# Altium schematic sheet ShielArchiK9V2.SchDoc(ShielArchiK9V2)
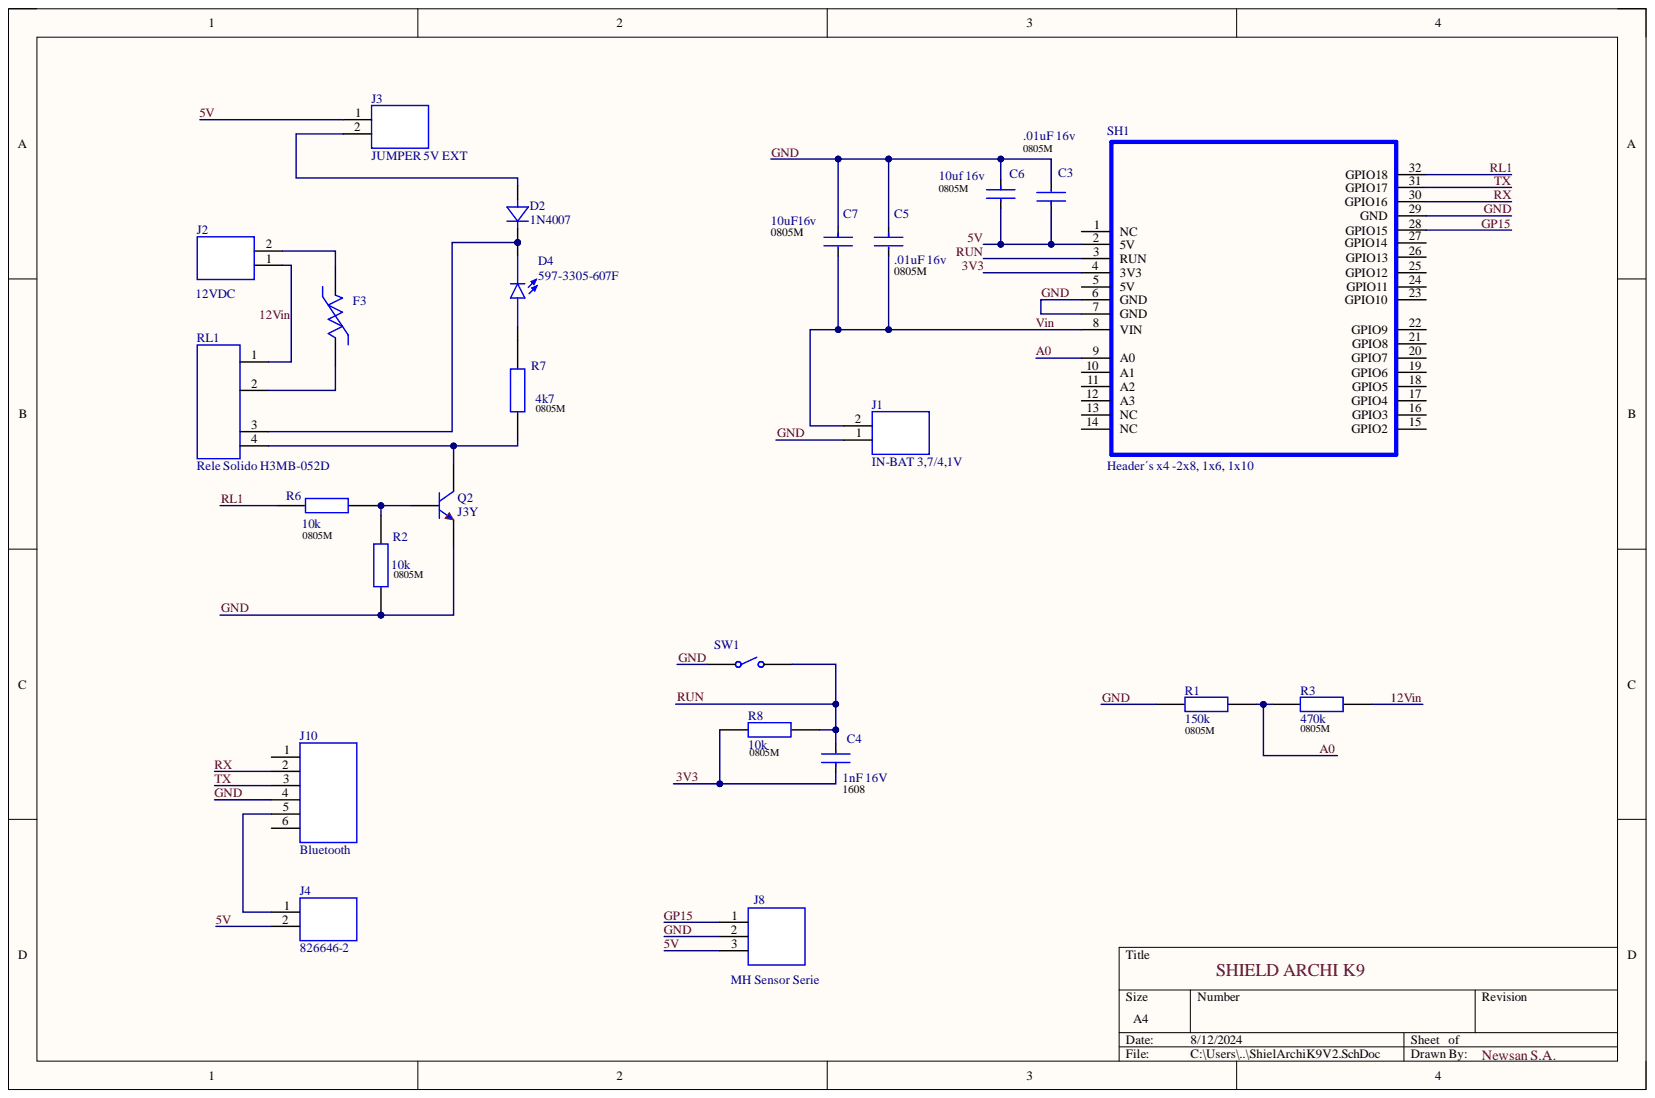
[diagram p0: ShielArchiK9V2.SchDoc]

## Parts
C3  .01uF 16v  [CC 106 10uf 16V 0805]
C4  1nF 16V  Voltage: 16V  [CC 106 10uf 16V 0805]
C5  .01uF 16v  [CC 106 10uf 16V 0805]
C6  10uf 16v  [CC 106 10uf 16V 0805]
C7  10uF16v  [CC 106 10uf 16V 0805]
D2  1N4007  [SOD123-M]
D4  597-3305-607F  [LEDC2012X70N]
F3  MF-MSMF050-2  [FP-MF-MSMF050-2-MFG]  (value not drawn)
J1  IN-BAT 3,7/4,1V  [PHOE-1729128-2_V]
J2  12VDC  [PHOE-1729128-2_V]
J3  JUMPER 5V EXT  [SAMTEC-HTSW-102-07-X-S]
J4  826646-2  [SAMTEC-HTSW-102-07-X-S]
J8  826646-3  [Header 3P 2.54mm]  (value not drawn)
J10  Bluetooth  [Header 6P 2.54mm]
Q2  J3Y  [ONSC-SOT-23-3-318-08_V - duplicate]
R1  150k  [RESC1609X50X30ML10T20]
R2  10k  [RESC1609X50X30ML10T20]
R3  470k  [RESC1609X50X30ML10T20]
R6  10k  [RESC1609X50X30ML10T20]
R7  4k7  [RESC1609X50X30ML10T20]
R8  10k  [RESC1609X50X30ML10T20]
RL1  Rele Solido H3MB-052D  [Rele Solido]
SH1  Header´s x4 -2x8, 1x6, 1x10  [Shield_ArchiV1]
SW1  147694-1  [TE_FSM8JRT]  (value not drawn)

## Nets
3V3: C4.1 R8.2 SH1.4(3V3)
5V: C3.1 C6.2 J3.1 J4.2 J8.3 SH1.2(5V)
12Vin: J2.1 R3.1 RL1.1
A0: R1.1 R3.2 SH1.9(A0)
GND: C3.2 C5.1 C6.1 C7.1 J1.1 J8.2 J10.4 Q2.2 R1.2 R2.1 SH1.6(GND) SH1.7(GND) SH1.29(GND) SW1.1
GP15: J8.1 SH1.28(GPIO15)
RL1: R6.1 SH1.32(GPIO18)
RUN: C4.2 R8.1 SH1.3(RUN) SW1.2
RX: J10.2 SH1.30(GPIO16)
TX: J10.3 SH1.31(GPIO17)
Vin: C5.2 C7.2 J1.2 SH1.8(VIN)
NetD2_1: D2.1 D4.C RL1.3
NetD2_2: D2.2 J3.2
NetD4_A: D4.A R7.1
NetF3_1: F3.1 RL1.2
NetF3_2: F3.2 J2.2
NetJ4_1: J4.1 J10.5
NetQ2_1: Q2.1 R2.2 R6.2
NetQ2_3: Q2.3 R7.2 RL1.4

Not connected: J10 1 6; SH1 1(NC) 5(5V) 10(A1) 11(A2) 12(A3) 13(NC) 14(NC) 15(GPIO2) 16(GPIO3) 17(GPIO4) 18(GPIO5) 19(GPIO6) 20(GPIO7) 21(GPIO8) 22(GPIO9) 23(GPIO10) 24(GPIO11) 25(GPIO12) 26(GPIO13) 27(GPIO14)

## Library data of the parts
C3-C7 = CC 106 10uf 16V 0805: Chip Multilayer Ceramic Capacitors for General Purpose, 0805, 10uF, X5R, 15%, 10%, 16V; Capacitance: 10uF; Case Code (Imperial): 0805; Case Code (Metric): 2012; Case/Package: 0805; Component Kind: Standard; Depth: 1.25mm; Dielectric: X5R; Height: 1.25mm; Lead Free: Lead Free; Length: 2mm; Material: Ceramic; Max Operating Temperature: 85°C; Min Operating Temperature: -55°C; Mount: Surface Mount; Package Quantity: 1; Packaging: Tape and Reel; Radiation Hardening: No; REACH SVHC: No SVHC; Termination: SMD/SMT; Thickness: 0.053inch; Tolerance: 10%; Voltage Rating: 16V; Voltage Rating (DC): 16V; Width: 1.25mm; Library Name: LibrMasterLab.IntLib
  C3 C5-C7: Voltage: 16V
D2 = 1N4007: Diode Standard Surface Mount SOD-123; MF: Micro Commercial; MP: 1N4148W-TP; Availability: Unavailable; Component Kind: Standard; Package: SOD-123 Microsemi; Price: None; SnapEDA_Link: https://www.snapeda.com/parts/1N4148W-TP/Micro%20Commercial/view-part/232376/?ref=snap; Library Name: LibrMasterLab.IntLib
D4 = 597-3305-607F: LED Uni-Color Green 4-Pin Chip 0805 T/R; Availability: Unavailable; Component Kind: Standard; MP: 597-3305-607F; Package: None; Price: None; Library Name: LibrMasterLab.IntLib
F3 = CMP-2100-03653-2: PTC RESET FUSE 15V 3A 1812; Manufacturer: Bourns; Automotive Grade: Grade 3; Current Rating: 100A; Hold Current: 0.5A; Resistance: 0.15-1Ω; Trip Current: 1A; Trip Time: 0.15s; Value: 0.15-1Ω; Ambient Temperature Range High: +85°C; Ambient Temperature Range Low: -40°C; Automotive: Yes; Category: Fuse; Component Kind: Standard; ComponentLink2Description: Datasheet; ComponentLink2URL: http://www.bourns.com/docs/Product-Datasheets/mfmsmf.pdf; Device Class L1: Circuit Protection; Device Class L2: PTC Resettable Fuses; Device Class L3: unset; Digikey Description: PTC RESET FUSE 15V 500MA 1812; Height: 0.85mm; Lead Free: Yes; Library Name: Altium Content Vault; Manufacturer Part Number: MF-MSMF050-2; Mouser Description: PTC Resettable Fuse 0.5A(hold) 1A(trip) 15V 100A 0.8W 0.15s 0.15Ohm SMD Solder Pad 1812 T/R; Octopart: https://octopart.com/mf-msmf050-2-bourns-28047; Package: 1812; RoHS: TRUE; Temperature: -40°C to +85°C; Temperature Range High: +85°C; Temperature Range Low: -40°C; Voltage Rating: 15V
J1 J2 = Bornera 2P 5mm: Terminal bloc vertical  2 P  Pitch 5mm; Component Kind: Standard; Library Name: LibrMasterLab1.IntLib
J3 = Header 2P 2.54mm: Conn Unshrouded Header HDR 2 POS 2.54mm Solder ST Thru-Hole; Centerline_Pitch: 2.54 mm[.1 in]; MF: TE Connectivity; MP: 826646-2; Number_of_Positions: 2; Product_Type: Connector; Availability: Good; Component Kind: Standard; DigiKey-Purchase-URL: https://snapeda.com/shop?store=DigiKey&id=470405; EU_RoHS_Compliance: Compliant; Mouser-Purchase-URL: https://snapeda.com/shop?store=Mouser&id=470405; Package: None; Price: None; SnapEDA_Link: https://www.snapeda.com/parts/826646-2/TE%20Connectivity%20AMP%20Connectors/view-part/470405/?ref=snap; Library Name: LibrMasterLab.IntLib
J4 = Header 2P 2.54mm: Conn Unshrouded Header HDR 2 POS 2.54mm Solder ST Thru-Hole; Centerline_Pitch: 2.54 mm[.1 in]; MF: TE Connectivity; Number_of_Positions: 2; Product_Type: Connector; Availability: Good; Component Kind: Standard; DigiKey-Purchase-URL: https://snapeda.com/shop?store=DigiKey&id=470405; EU_RoHS_Compliance: Compliant; Mouser-Purchase-URL: https://snapeda.com/shop?store=Mouser&id=470405; MP: 826646-2; Package: None; Price: None; SnapEDA_Link: https://www.snapeda.com/parts/826646-2/TE%20Connectivity%20AMP%20Connectors/view-part/470405/?ref=snap; Library Name: LibrMasterLab.IntLib
J8 = Header 3P 2.54mm: Conn Unshrouded Header HDR 3 POS 2.54mm Solder ST Thru-Hole; Component Kind: Standard; Library Name: LibrMasterLab.IntLib
J10 = Header 6P 2.54mm: 2.5 M.I.S POST HDR ASSY 6P; Centerline_Pitch: 2.5 mm[.098 in]; MF: TE Connectivity; MP: 177537-6; Number_of_Positions: 6; Product_Type: Connector; Availability: Unavailable; Component Kind: Standard; EU_RoHS_Compliance: Compliant; Package: None; Price: None; SnapEDA_Link: https://www.snapeda.com/parts/177537-6/TE%20Connectivity/view-part/563502/?ref=snap; TE_purchase_URL: https://www.te.com/usa-en/product-177537-6.html?te_bu=Cor&te_type=disp&te_campaign=seda_glo_cor-seda-global-disp-prtnr-fy19-seda-model-bom-cta_sma-317_1&elqCampaignId=32493; Library Name: LibrMasterLab.IntLib
Q2 = CMP-1048-00625-1: General Purpose Transistor, NPN Silicon, 3-Pin SOT-23, Pb-Free, Tape and Reel; Manufacturer: On Semiconductor; PartNumber: SMMBT3904LT1G; fT Min (MHz): 300; IC Continuous (A): 0.2; Component Kind: Standard; ComponentLink1Description: Manufacturer URL; ComponentLink1URL: http://www.onsemi.com/; ComponentLink2Description: Datasheet; ComponentLink2URL: http://www.onsemi.cn/pub_link/Collateral/MMBT3904LT1-D.PDF; ComponentLink3Description: Package Specification; ComponentLink3URL: http://www.onsemi.cn/pub_link/Collateral/318-08.PDF; DatasheetVersion: Rev. 12; Mounting Technology: Surface Mount; PackageDescription: 3-Pin Small Outline Transistor, Body 2.92 x 1.3 mm, Pitch 0.95 mm; PackageReference: SOT-23-3-318-08; PackageVersion: Rev. AP, 11/2009; Packing: Tape and Reel; Polarity: NPN; RoHS: TRUE; Library Name: LibrMasterLab.IntLib
R1-R3 R6-R8 = Resistor 510 ohms: Resisto 510ohms 0603 (1608 m); Manufacturer: Vishay Dale; Part Number: CRCW0603510RFKEA; Component Kind: Standard; Library Name: LibrMasterLab.IntLib
RL1 = Rele Solido H3MB-052D: INPUT3-24VDC - OUPUT 2A/50VDC; Component Kind: Standard; Library Name: LibrMasterLab1.IntLib
SH1 = ARCHI_SHIELD: Header´s 1x6P2.54mm - 2x8P2.54mm - 1x10P2.54mm; Component Kind: Standard; Library Name: LibrMasterLab.IntLib
SW1 = 147694-1: Switch Tactile OFF (ON) SPST Round Button PC Pins 0.05A 12VDC 1.57N Thru-Hole Radial Tape; MF: TE Connectivity; Availability: In Stock; Check_prices: https://www.snapeda.com/parts/147694-1/TE+Connectivity+ALCOSWITCH+Switches/view-part/?ref=eda; Component Kind: Standard; MP: 147694-1; Package: None; Price: None; Purchase-URL: https://pricing.snapeda.com/search/part/147694-1/?ref=eda; SnapEDA_Link: https://www.snapeda.com/parts/147694-1/TE+Connectivity+ALCOSWITCH+Switches/view-part/?ref=snap; Library Name: LibrMasterLab1.IntLib
